# Altium schematic sheet Sheet1.SchDoc(Sheet1)
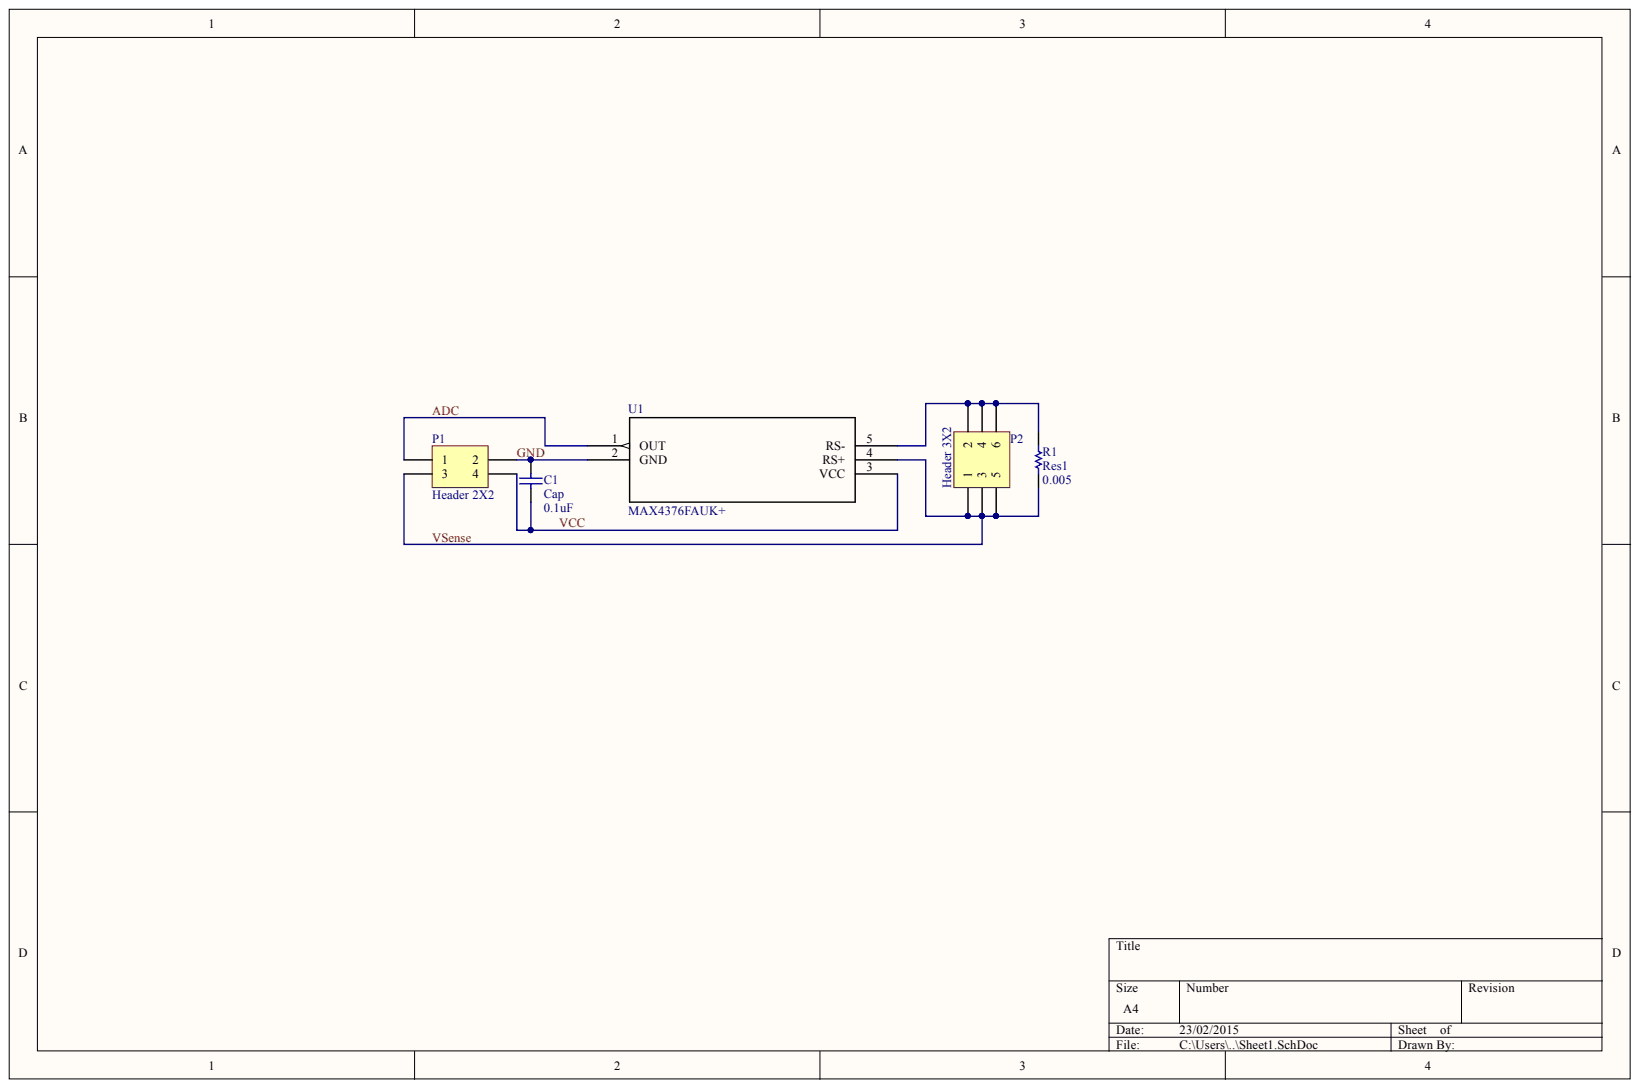
[diagram p0: Sheet1.SchDoc]

## Parts
C1  Cap  value 0.1uF  [C1206]
P1  Header 2X2  [HDR2X2]
P2  Header 3X2  [HDR2X3]
R1  Res1  value 0.005  [AXIAL-0.3]
U1  MAX4376FAUK+  [21-0057F]

## Nets
ADC: P1.1 U1.1(OUT)
GND: C1.2 P1.2 U1.2(GND)
VCC: C1.1 P1.4 U1.3(VCC)
VSENSE: P1.3 P2.1 P2.3 P2.5 R1.1 U1.4(RS+)
NetP2_2: P2.2 P2.4 P2.6 R1.2 U1.5(RS-)

## Library data of the parts
C1 = Cap Semi: Capacitor (Semiconductor SIM Model); Component Kind: Standard; LatestRevisionDate: 29-May-2009; LatestRevisionNote: IPC-7351 Footprint Added.; Library Name: Miscellaneous Devices.IntLib; PackageDescription: Chip Capacitor; PackageReference: C1206; Published: 8-Jun-2000; Signal Integrity: Cap Semi; Simulation: CAP; Publisher: Altium Limited
P1 = Header 2X2: Header, 2-Pin, Dual row; Component Kind: Standard; LatestRevisionDate: 17-Jul-2002; LatestRevisionNote: Re-released for DXP Platform.; Library Name: Miscellaneous Connectors.IntLib; Signal Integrity: Connector; Publisher: Altium Limited
P2 = Header 3X2: Header, 3-Pin, Dual row; Component Kind: Standard; LatestRevisionDate: 17-Jul-2002; LatestRevisionNote: Re-released for DXP Platform.; Library Name: Miscellaneous Connectors.IntLib; Signal Integrity: Connector; Publisher: Altium Limited
R1 = Res1: Resistor; Component Kind: Standard; LatestRevisionDate: 17-Jul-2002; LatestRevisionNote: Re-released for DXP Platform.; Library Name: Miscellaneous Devices.IntLib; PackageDescription: Axial Device, Thru-Hole; 2 Leads; 0.3 in Pin Spacing; PackageReference: AXIAL-0.3; Published: 8-Jun-2000; Signal Integrity: Res; Simulation: RESISTOR; Publisher: Altium Limited
U1 = MAX4376FAUK+: Imported; Vendor: MAXIM; Component Kind: Standard; Library Name: Schlib.SchLib; Manufacturer_Part_Number: max4376fauk+; RefDes: RefDes; Type: DEV; Value: Value
